# Altium schematic sheet Comm_Interface.SchDoc
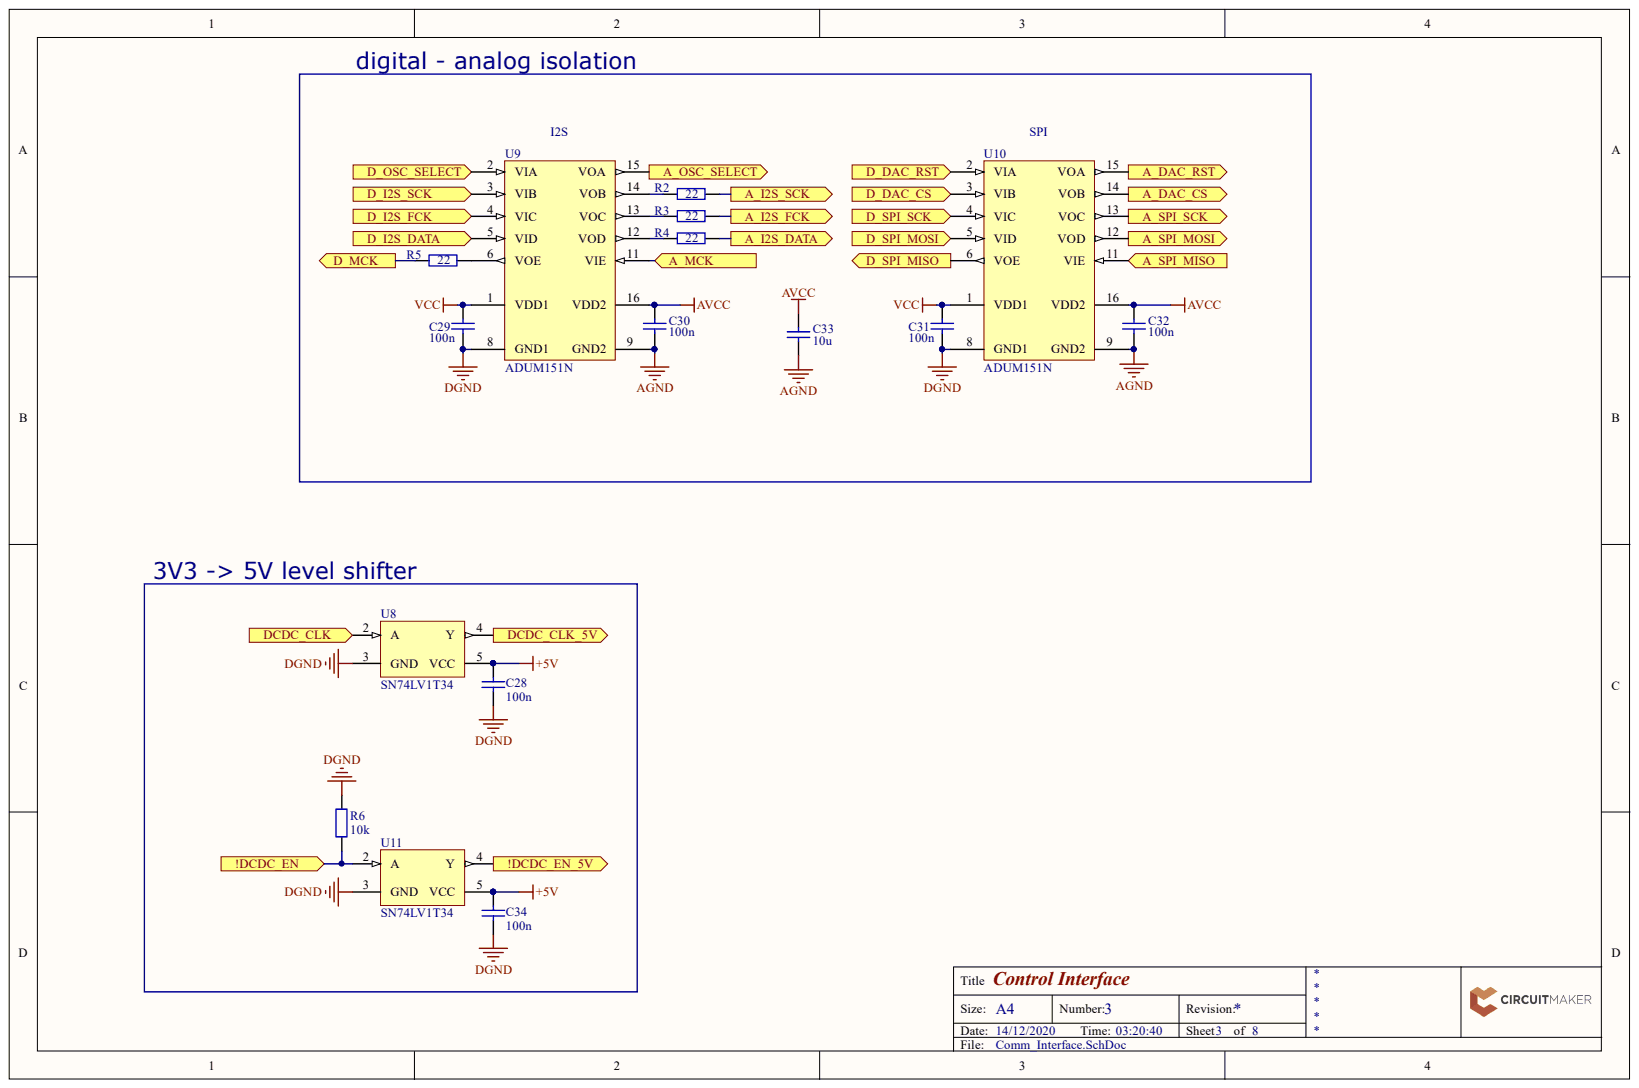
[diagram p0: Comm_Interface.SchDoc]

## Parts
C28  100n  [PCB-rf0pzaa82dpbeu9l4sxm-1]
C29  100n  [PCB-rf0pzaa82dpbeu9l4sxm-1]
C30  100n  [PCB-rf0pzaa82dpbeu9l4sxm-1]
C31  100n  [PCB-rf0pzaa82dpbeu9l4sxm-1]
C32  100n  [PCB-rf0pzaa82dpbeu9l4sxm-1]
C33  10u  [PCB-rf0pzaa82dpbeu9l4sxm-1]
C34  100n  [PCB-rf0pzaa82dpbeu9l4sxm-1]
R2  22  [PCB-3d5y3t7f8drf35yjcj59-1]
R3  22  [PCB-3d5y3t7f8drf35yjcj59-1]
R4  22  [PCB-3d5y3t7f8drf35yjcj59-1]
R5  22  [PCB-3d5y3t7f8drf35yjcj59-1]
R6  10k  [PCB-3d5y3t7f8drf35yjcj59-1]
U8  SN74LV1T34  [PCB-0ardthfs7lzl2yengne1-1]
U9  ADUM151N  [SOP16]
U10  ADUM151N  [SOP16]
U11  SN74LV1T34  [PCB-0ardthfs7lzl2yengne1-1]

## Ports
!DCDC_EN, !DCDC_EN_5V, A_DAC_CS, A_DAC_RST, A_I2S_DATA, A_I2S_FCK, A_I2S_SCK, A_MCK, A_OSC_SELECT, A_SPI_MISO, A_SPI_MOSI, A_SPI_SCK, D_DAC_CS, D_DAC_RST, D_I2S_DATA, D_I2S_FCK, D_I2S_SCK, D_MCK, D_OSC_SELECT, D_SPI_MISO, D_SPI_MOSI, D_SPI_SCK, DCDC_CLK, DCDC_CLK_5V

## Nets
+5V: C28.2 C34.2 U8.5(VCC) U11.5(VCC)
AGND: C30.1 C32.1 C33.1 U9.9(GND2) U10.9(GND2)
AVCC: C30.2 C32.2 C33.2 U9.16(VDD2) U10.16(VDD2)
DGND: C28.1 C29.1 C31.1 C34.1 R6.2 U8.3(GND) U9.8(GND1) U10.8(GND1) U11.3(GND)
VCC: C29.2 C31.2 U9.1(VDD1) U10.1(VDD1)
!DCDC_EN: R6.1 U11.2(A) ; port !DCDC_EN
!DCDC_EN_5V: U11.4(Y) ; port !DCDC_EN_5V
A_DAC_CS: U10.14(VOB) ; port A_DAC_CS
A_DAC_RST: U10.15(VOA) ; port A_DAC_RST
A_I2S_DATA: R4.1 ; port A_I2S_DATA
A_I2S_FCK: R3.1 ; port A_I2S_FCK
A_I2S_SCK: R2.1 ; port A_I2S_SCK
A_MCK: U9.11(VIE) ; port A_MCK
A_OSC_SELECT: U9.15(VOA) ; port A_OSC_SELECT
A_SPI_MISO: U10.11(VIE) ; port A_SPI_MISO
A_SPI_MOSI: U10.12(VOD) ; port A_SPI_MOSI
A_SPI_SCK: U10.13(VOC) ; port A_SPI_SCK
D_DAC_CS: U10.3(VIB) ; port D_DAC_CS
D_DAC_RST: U10.2(VIA) ; port D_DAC_RST
D_I2S_DATA: U9.5(VID) ; port D_I2S_DATA
D_I2S_FCK: U9.4(VIC) ; port D_I2S_FCK
D_I2S_SCK: U9.3(VIB) ; port D_I2S_SCK
D_MCK: R5.2 ; port D_MCK
D_OSC_SELECT: U9.2(VIA) ; port D_OSC_SELECT
D_SPI_MISO: U10.6(VOE) ; port D_SPI_MISO
D_SPI_MOSI: U10.5(VID) ; port D_SPI_MOSI
D_SPI_SCK: U10.4(VIC) ; port D_SPI_SCK
DCDC_CLK: U8.2(A) ; port DCDC_CLK
DCDC_CLK_5V: U8.4(Y) ; port DCDC_CLK_5V
NetR2_2: R2.2 U9.14(VOB)
NetR3_2: R3.2 U9.13(VOC)
NetR4_2: R4.2 U9.12(VOD)
NetR5_1: R5.1 U9.6(VOE)

## Library data of the parts
C28-C34 = CMP-x8hddryxw4o380xfi98v-1: Capacitor 0603; Component Kind: Standard; CustomComponentID: x8hddryxw4o380xfi98v; LCSC Part #: C19702; Library Name: FavoritesLibrary
  C28-C32 C34: LCSC Part #: C109474; LCSC Part #: C14663
R2-R6 = CMP-xcu3s4ikiffkkgbeyow5-1: Resistor 0603; Component Kind: Standard; CustomComponentID: xcu3s4ikiffkkgbeyow5; Library Name: FavoritesLibrary
  R2-R5: LCSC Part #: C23345
  R6: LCSC Part #: C23265; LCSC Part #: C1546; LCSC Part #: C81598; LCSC Part #: C21190; LCSC Part #: C19702; LCSC Part #: C25804
U8 U11 = CMP-3a3bf2ca9c96e5fc-1: Single Power Supply BUFFER Logic Level Shifter (no enable) 5-SC70 -40 to 125; Manufacturer Part Number: SN74LV1T34DCKR; Lead-Free Status: Lead Free; Component Kind: Standard; Library Name: CommunityVault; Lifecycle Status: Active; OctopartID: 3a3bf2ca9c96e5fc; Octopart URL: http://octopart.com/sn74lv1t34dckr-texas+instruments-33260491; RoHS: Compliant
U9 U10 = CMP-8a0e4768d24455c9-3: Digital Isolator CMOS 5-CH 150Mbps 16-Pin SOIC N Tube; Lead-Free Status: Contains Lead; Component Kind: Standard; Library Name: CommunityVault; Lifecycle Status: Pre-Release; Number of Pins: 16; OctopartID: 8a0e4768d24455c9; Octopart URL: https://octopart.com/adum151n0brz-analog+devices-74218796; Operating Temperature: -40.0 °C (min); Packaging: Tube; RoHS: Compliant
